# Altium schematic sheet ANALOG-FRONTEND.SchDoc
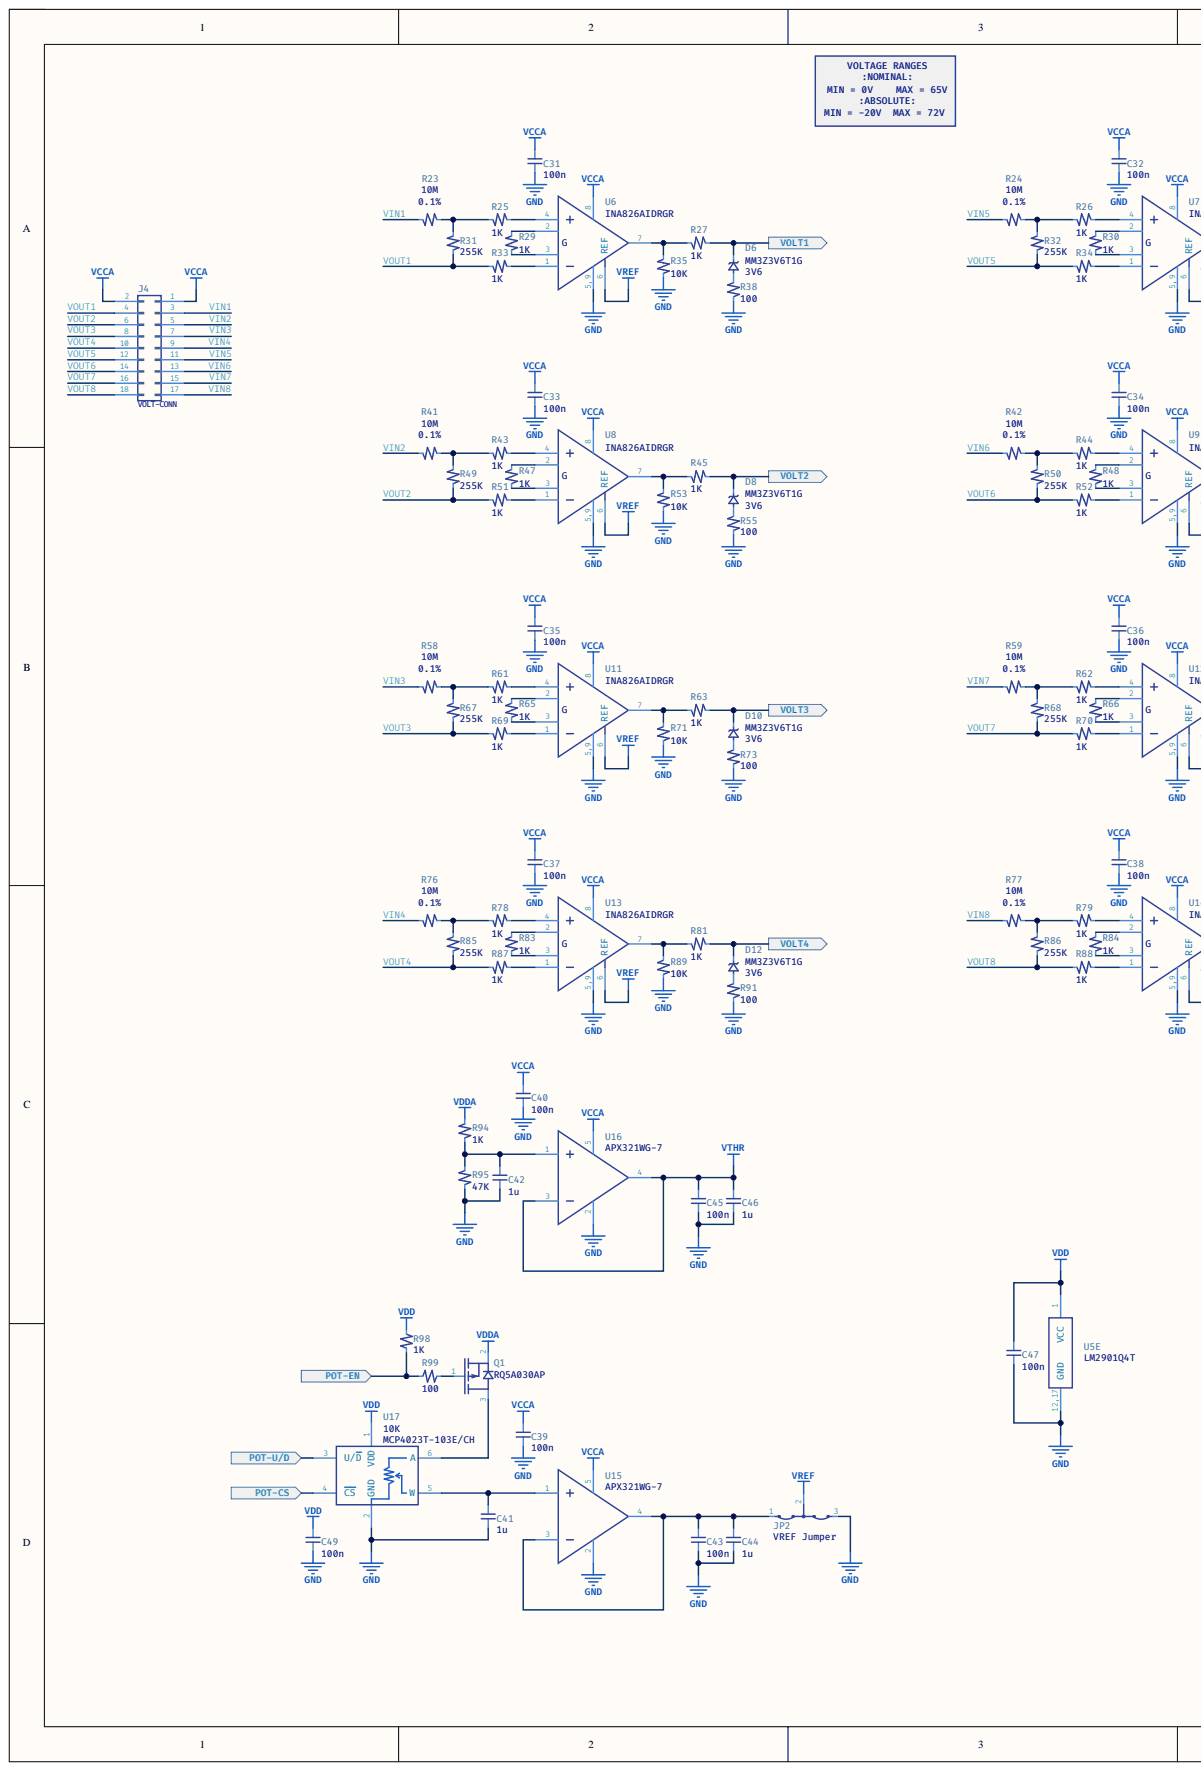
[diagram p0: ANALOG-FRONTEND.SchDoc, left]
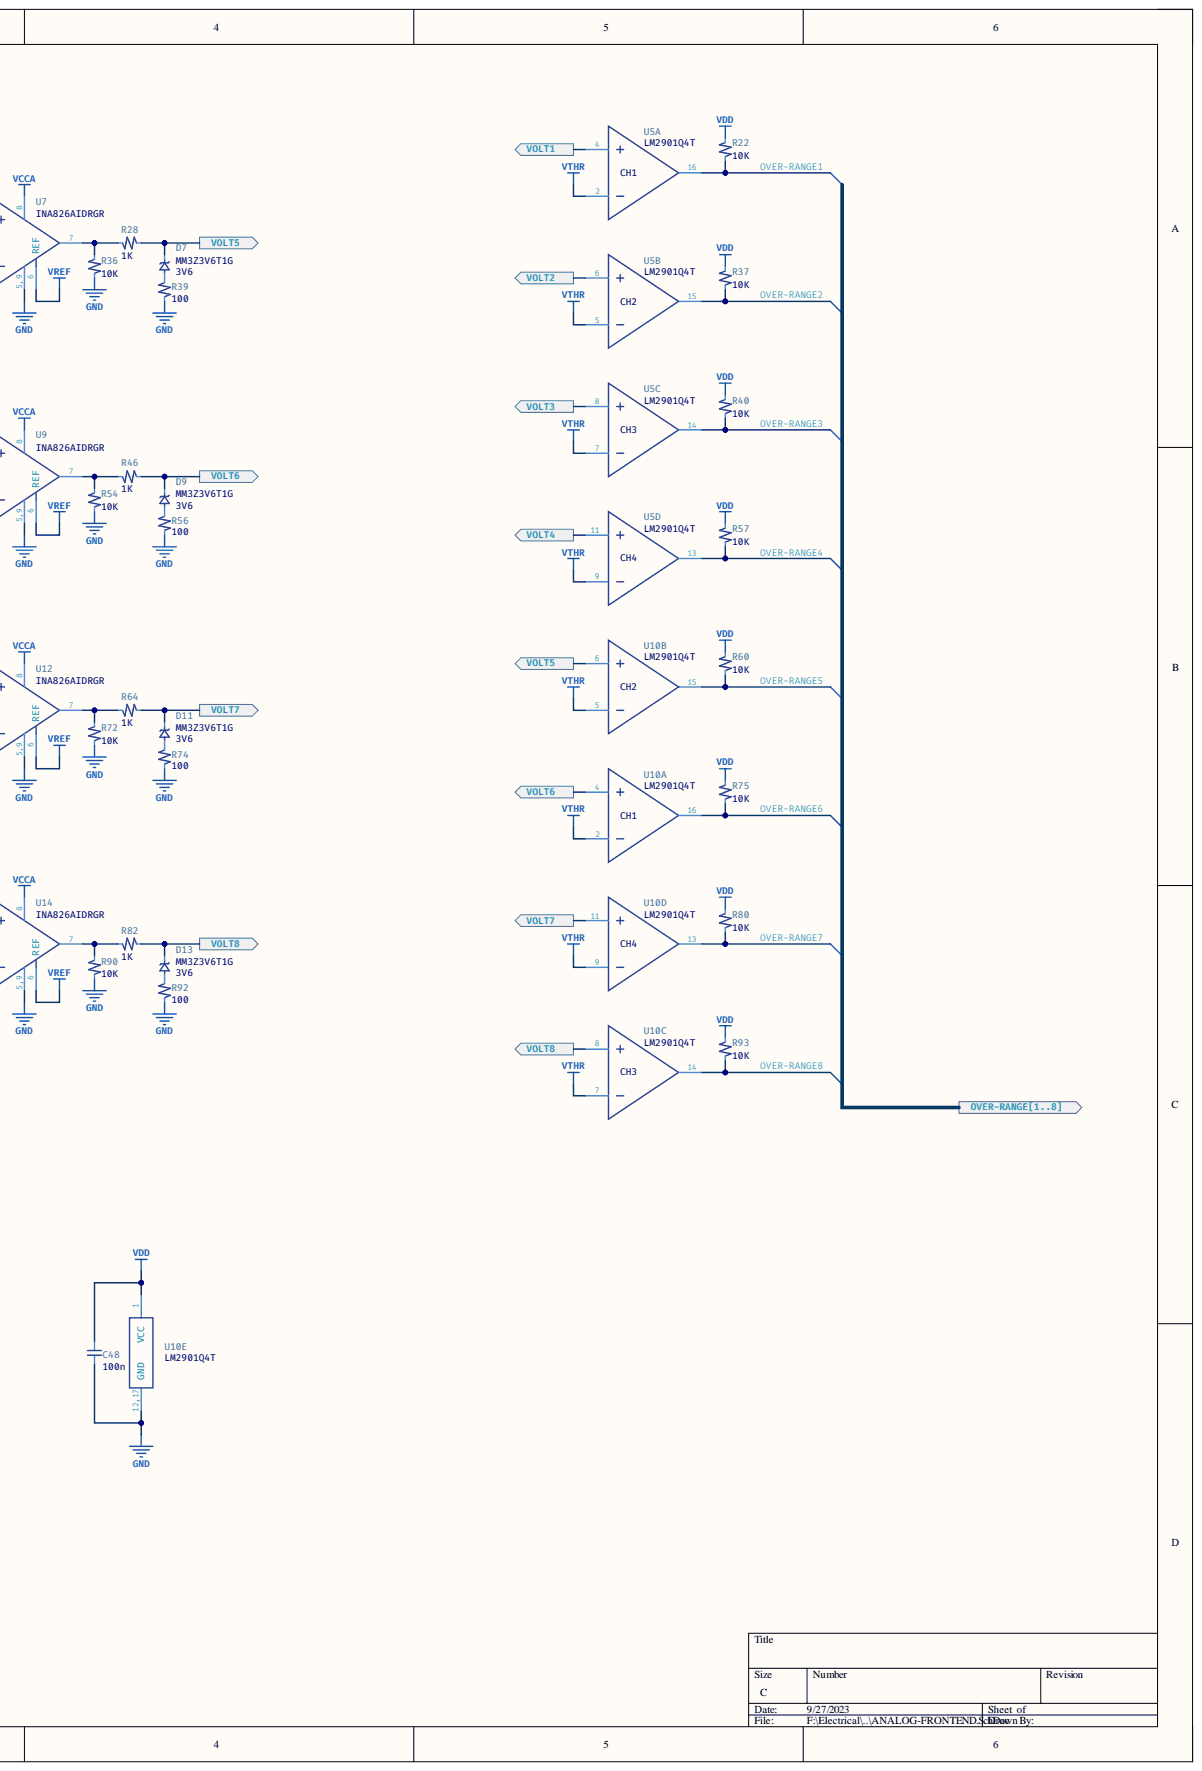
[diagram p1: ANALOG-FRONTEND.SchDoc, right]

## Parts
C31  value 100n  [CAP-0402]  (hidden comment: Generic Capacitor)
C32  value 100n  [CAP-0402]  (hidden comment: Generic Capacitor)
C33  value 100n  [CAP-0402]  (hidden comment: Generic Capacitor)
C34  value 100n  [CAP-0402]  (hidden comment: Generic Capacitor)
C35  value 100n  [CAP-0402]  (hidden comment: Generic Capacitor)
C36  value 100n  [CAP-0402]  (hidden comment: Generic Capacitor)
C37  value 100n  [CAP-0402]  (hidden comment: Generic Capacitor)
C38  value 100n  [CAP-0402]  (hidden comment: Generic Capacitor)
C39  value 100n  [CAP-0402]  (hidden comment: Generic Capacitor)
C40  value 100n  [CAP-0402]  (hidden comment: Generic Capacitor)
C41  value 1u  [CAP-0603]  (hidden comment: Generic Capacitor)
C42  value 1u  [CAP-0603]  (hidden comment: Generic Capacitor)
C43  value 100n  [CAP-0402]  (hidden comment: Generic Capacitor)
C44  value 1u  [CAP-0603]  (hidden comment: Generic Capacitor)
C45  value 100n  [CAP-0402]  (hidden comment: Generic Capacitor)
C46  value 1u  [CAP-0603]  (hidden comment: Generic Capacitor)
C47  value 100n  [CAP-0402]  (hidden comment: Generic Capacitor)
C48  value 100n  [CAP-0402]  (hidden comment: Generic Capacitor)
C49  value 100n  [CAP-0402]  (hidden comment: Generic Capacitor)
D6  value MM3Z3V6T1G  Supplier Part Number 9: MM3Z3V6T1G  VZ: 3V6  [D-SOD-323]  (hidden comment: 3.6V Zener Diode)
D7  value MM3Z3V6T1G  Supplier Part Number 9: MM3Z3V6T1G  VZ: 3V6  [D-SOD-323]  (hidden comment: 3.6V Zener Diode)
D8  value MM3Z3V6T1G  Supplier Part Number 9: MM3Z3V6T1G  VZ: 3V6  [D-SOD-323]  (hidden comment: 3.6V Zener Diode)
D9  value MM3Z3V6T1G  Supplier Part Number 9: MM3Z3V6T1G  VZ: 3V6  [D-SOD-323]  (hidden comment: 3.6V Zener Diode)
D10  value MM3Z3V6T1G  Supplier Part Number 9: MM3Z3V6T1G  VZ: 3V6  [D-SOD-323]  (hidden comment: 3.6V Zener Diode)
D11  value MM3Z3V6T1G  Supplier Part Number 9: MM3Z3V6T1G  VZ: 3V6  [D-SOD-323]  (hidden comment: 3.6V Zener Diode)
D12  value MM3Z3V6T1G  Supplier Part Number 9: MM3Z3V6T1G  VZ: 3V6  [D-SOD-323]  (hidden comment: 3.6V Zener Diode)
D13  value MM3Z3V6T1G  Supplier Part Number 9: MM3Z3V6T1G  VZ: 3V6  [D-SOD-323]  (hidden comment: 3.6V Zener Diode)
J4  value VOLT-CONN  (hidden comment: Conn_02x09_Odd_Even)
JP2  value VREF Jumper  [PinHeader_1x03_P2.54mm_Vertical]  (hidden comment: VREF Selection Jumper)
Q1  value RQ5A030AP  [SOT-23-3]  (hidden comment: P-Ch SIG MOSFET 12V 3A)
R22  value 10K  [RES-0402]  (hidden comment: General Resistor)
R23  value 10M  Tolerance: 0.1%  [RES-1206]  (hidden comment: High Stability Precision Resistor)
R24  value 10M  Tolerance: 0.1%  [RES-1206]  (hidden comment: High Stability Precision Resistor)
R25  value 1K  [RES-0402]  (hidden comment: General Resistor)
R26  value 1K  [RES-0402]  (hidden comment: General Resistor)
R27  value 1K  [RES-0402]  (hidden comment: General Resistor)
R28  value 1K  [RES-0402]  (hidden comment: General Resistor)
R29  value 1K  [RES-0402]  (hidden comment: General Resistor)
R30  value 1K  [RES-0402]  (hidden comment: General Resistor)
R31  value 255K  [RES-1206]  (hidden comment: Precision Thin Film Resistor)
R32  value 255K  [RES-1206]  (hidden comment: Precision Thin Film Resistor)
R33  value 1K  [RES-0402]  (hidden comment: General Resistor)
R34  value 1K  [RES-0402]  (hidden comment: General Resistor)
R35  value 10K  [RES-0402]  (hidden comment: General Resistor)
R36  value 10K  [RES-0402]  (hidden comment: General Resistor)
R37  value 10K  [RES-0402]  (hidden comment: General Resistor)
R38  value 100  [RES-0402]  (hidden comment: General Resistor)
R39  value 100  [RES-0402]  (hidden comment: General Resistor)
R40  value 10K  [RES-0402]  (hidden comment: General Resistor)
R41  value 10M  Tolerance: 0.1%  [RES-1206]  (hidden comment: High Stability Precision Resistor)
R42  value 10M  Tolerance: 0.1%  [RES-1206]  (hidden comment: High Stability Precision Resistor)
R43  value 1K  [RES-0402]  (hidden comment: General Resistor)
R44  value 1K  [RES-0402]  (hidden comment: General Resistor)
R45  value 1K  [RES-0402]  (hidden comment: General Resistor)
R46  value 1K  [RES-0402]  (hidden comment: General Resistor)
R47  value 1K  [RES-0402]  (hidden comment: General Resistor)
R48  value 1K  [RES-0402]  (hidden comment: General Resistor)
R49  value 255K  [RES-1206]  (hidden comment: Precision Thin Film Resistor)
R50  value 255K  [RES-1206]  (hidden comment: Precision Thin Film Resistor)
R51  value 1K  [RES-0402]  (hidden comment: General Resistor)
R52  value 1K  [RES-0402]  (hidden comment: General Resistor)
R53  value 10K  [RES-0402]  (hidden comment: General Resistor)
R54  value 10K  [RES-0402]  (hidden comment: General Resistor)
R55  value 100  [RES-0402]  (hidden comment: General Resistor)
R56  value 100  [RES-0402]  (hidden comment: General Resistor)
R57  value 10K  [RES-0402]  (hidden comment: General Resistor)
R58  value 10M  Tolerance: 0.1%  [RES-1206]  (hidden comment: High Stability Precision Resistor)
R59  value 10M  Tolerance: 0.1%  [RES-1206]  (hidden comment: High Stability Precision Resistor)
R60  value 10K  [RES-0402]  (hidden comment: General Resistor)
R61  value 1K  [RES-0402]  (hidden comment: General Resistor)
R62  value 1K  [RES-0402]  (hidden comment: General Resistor)
R63  value 1K  [RES-0402]  (hidden comment: General Resistor)
R64  value 1K  [RES-0402]  (hidden comment: General Resistor)
R65  value 1K  [RES-0402]  (hidden comment: General Resistor)
R66  value 1K  [RES-0402]  (hidden comment: General Resistor)
R67  value 255K  [RES-1206]  (hidden comment: Precision Thin Film Resistor)
R68  value 255K  [RES-1206]  (hidden comment: Precision Thin Film Resistor)
R69  value 1K  [RES-0402]  (hidden comment: General Resistor)
R70  value 1K  [RES-0402]  (hidden comment: General Resistor)
R71  value 10K  [RES-0402]  (hidden comment: General Resistor)
R72  value 10K  [RES-0402]  (hidden comment: General Resistor)
R73  value 100  [RES-0402]  (hidden comment: General Resistor)
R74  value 100  [RES-0402]  (hidden comment: General Resistor)
R75  value 10K  [RES-0402]  (hidden comment: General Resistor)
R76  value 10M  Tolerance: 0.1%  [RES-1206]  (hidden comment: High Stability Precision Resistor)
R77  value 10M  Tolerance: 0.1%  [RES-1206]  (hidden comment: High Stability Precision Resistor)
R78  value 1K  [RES-0402]  (hidden comment: General Resistor)
R79  value 1K  [RES-0402]  (hidden comment: General Resistor)
R80  value 10K  [RES-0402]  (hidden comment: General Resistor)
R81  value 1K  [RES-0402]  (hidden comment: General Resistor)
R82  value 1K  [RES-0402]  (hidden comment: General Resistor)
R83  value 1K  [RES-0402]  (hidden comment: General Resistor)
R84  value 1K  [RES-0402]  (hidden comment: General Resistor)
R85  value 255K  [RES-1206]  (hidden comment: Precision Thin Film Resistor)
R86  value 255K  [RES-1206]  (hidden comment: Precision Thin Film Resistor)
R87  value 1K  [RES-0402]  (hidden comment: General Resistor)
R88  value 1K  [RES-0402]  (hidden comment: General Resistor)
R89  value 10K  [RES-0402]  (hidden comment: General Resistor)
R90  value 10K  [RES-0402]  (hidden comment: General Resistor)
R91  value 100  [RES-0402]  (hidden comment: General Resistor)
R92  value 100  [RES-0402]  (hidden comment: General Resistor)
R93  value 10K  [RES-0402]  (hidden comment: General Resistor)
R94  value 1K  [RES-0402]  (hidden comment: General Resistor)
R95  value 47K  [RES-0402]  (hidden comment: General Resistor)
R98  value 1K  [RES-0402]  (hidden comment: General Resistor)
R99  value 100  [RES-0402]  (hidden comment: General Resistor)
U5  value LM2901Q4T  [QFN-16-1EP_3x3mm_P0.5mm_EP1.7x1.7mm]  (hidden comment: IC COMPARATOR 4 GP)  (parts A,B,C,D,E)
U6  value INA826AIDRGR  [DFN-8-1EP_3x3mm_P0.5mm_EP1.2x2mm]  (hidden comment: IC INST AMP 1 CIRCUIT)
U7  value INA826AIDRGR  [DFN-8-1EP_3x3mm_P0.5mm_EP1.2x2mm]  (hidden comment: IC INST AMP 1 CIRCUIT)
U8  value INA826AIDRGR  [DFN-8-1EP_3x3mm_P0.5mm_EP1.2x2mm]  (hidden comment: IC INST AMP 1 CIRCUIT)
U9  value INA826AIDRGR  [DFN-8-1EP_3x3mm_P0.5mm_EP1.2x2mm]  (hidden comment: IC INST AMP 1 CIRCUIT)
U10  value LM2901Q4T  [QFN-16-1EP_3x3mm_P0.5mm_EP1.7x1.7mm]  (hidden comment: IC COMPARATOR 4 GP)  (parts A,B,C,D,E)
U11  value INA826AIDRGR  [DFN-8-1EP_3x3mm_P0.5mm_EP1.2x2mm]  (hidden comment: IC INST AMP 1 CIRCUIT)
U12  value INA826AIDRGR  [DFN-8-1EP_3x3mm_P0.5mm_EP1.2x2mm]  (hidden comment: IC INST AMP 1 CIRCUIT)
U13  value INA826AIDRGR  [DFN-8-1EP_3x3mm_P0.5mm_EP1.2x2mm]  (hidden comment: IC INST AMP 1 CIRCUIT)
U14  value INA826AIDRGR  [DFN-8-1EP_3x3mm_P0.5mm_EP1.2x2mm]  (hidden comment: IC INST AMP 1 CIRCUIT)
U15  value APX321WG-7  [SOT-23-5]  (hidden comment: IC OPAMP GP 1 CIRCUIT)
U16  value APX321WG-7  [SOT-23-5]  (hidden comment: IC OPAMP GP 1 CIRCUIT)
U17  value MCP4023T-103E/CH  Resistance: 10K  [SOT-23-6]  (hidden comment: IC DGTL POT 10KOHM 64TAP)

## Ports
OVER-RANGE1, OVER-RANGE2, OVER-RANGE3, OVER-RANGE4, OVER-RANGE5, OVER-RANGE6, OVER-RANGE7, OVER-RANGE8, OVER-RANGE[1..8], POT-CS, POT-EN, POT-U/D, VOLT1, VOLT2, VOLT3, VOLT4, VOLT5, VOLT6, VOLT7, VOLT8

## Nets
GND: C31.2 C32.2 C33.2 C34.2 C35.2 C36.2 C37.2 C38.2 C39.2 C40.2 C41.2 C42.2 C43.2 C44.2 C45.2 C46.2 C47.2 C48.2 C49.2 JP2.3 R35.1 R36.1 R38.2 R39.2 R53.1 R54.1 R55.2 R56.2 R71.1 R72.1 R73.2 R74.2 R89.1 R90.1 R91.2 R92.2 R95.2 U5.12,17(GND) U6.5,9 U7.5,9 U8.5,9 U9.5,9 U10.12,17(GND) U11.5,9 U12.5,9 U13.5,9 U14.5,9 U15.2 U16.2 U17.2(GND)
VCCA: C31.1 C32.1 C33.1 C34.1 C35.1 C36.1 C37.1 C38.1 C39.1 C40.1 J4.1 J4.2 U6.8 U7.8 U8.8 U9.8 U11.8 U12.8 U13.8 U14.8 U15.5 U16.5
VDD: C47.1 C48.1 C49.1 R22.2 R37.2 R40.2 R57.2 R60.2 R75.2 R80.2 R93.2 R98.1 U5.1(VCC) U10.1(VCC) U17.1(VDD)
VDDA: Q1.2 R94.1
VREF: JP2.2 U6.6(REF) U7.6(REF) U8.6(REF) U9.6(REF) U11.6(REF) U12.6(REF) U13.6(REF) U14.6(REF)
VTHR: C45.1 C46.1 U5.2 U5.5 U5.7 U5.9 U10.2 U10.5 U10.7 U10.9 U16.3 U16.4
OVER-RANGE1: R22.1 U5.16 ; port OVER-RANGE[1..8]  [also labelled ]
OVER-RANGE2: R37.1 U5.15 ; port OVER-RANGE[1..8]  [also labelled ]
OVER-RANGE3: R40.1 U5.14 ; port OVER-RANGE[1..8]  [also labelled ]
OVER-RANGE4: R57.1 U5.13 ; port OVER-RANGE[1..8]  [also labelled ]
OVER-RANGE5: R60.1 U10.15 ; port OVER-RANGE[1..8]  [also labelled ]
OVER-RANGE6: R75.1 U10.16 ; port OVER-RANGE[1..8]  [also labelled ]
OVER-RANGE7: R80.1 U10.13 ; port OVER-RANGE[1..8]  [also labelled ]
OVER-RANGE8: R93.1 U10.14 ; port OVER-RANGE[1..8]  [also labelled ]
POT-CS: U17.4(CS) ; port POT-CS
POT-EN: R98.2 R99.2 ; port POT-EN
POT-U/D: U17.3(U/D) ; port POT-U/D
VIN1: J4.3 R23.2
VIN2: J4.5 R41.2
VIN3: J4.7 R58.2
VIN4: J4.9 R76.2
VIN5: J4.11 R24.2
VIN6: J4.13 R42.2
VIN7: J4.15 R59.2
VIN8: J4.17 R77.2
VOLT1: D6.1 R27.1 U5.4 ; port VOLT1
VOLT2: D8.1 R45.1 U5.6 ; port VOLT2
VOLT3: D10.1 R63.1 U5.8 ; port VOLT3
VOLT4: D12.1 R81.1 U5.11 ; port VOLT4
VOLT5: D7.1 R28.1 U10.6 ; port VOLT5
VOLT6: D9.1 R46.1 U10.4 ; port VOLT6
VOLT7: D11.1 R64.1 U10.11 ; port VOLT7
VOLT8: D13.1 R82.1 U10.8 ; port VOLT8
VOUT1: J4.4 R31.2 R33.2
VOUT2: J4.6 R49.2 R51.2
VOUT3: J4.8 R67.2 R69.2
VOUT4: J4.10 R85.2 R87.2
VOUT5: J4.12 R32.2 R34.2
VOUT6: J4.14 R50.2 R52.2
VOUT7: J4.16 R68.2 R70.2
VOUT8: J4.18 R86.2 R88.2
NetC41_1: C41.1 U15.1 U17.5(W)
NetC42_1: C42.1 R94.2 R95.1 U16.1
NetC43_1: C43.1 C44.1 JP2.1 U15.3 U15.4
NetD6_2: D6.2 R38.1
NetD7_2: D7.2 R39.1
NetD8_2: D8.2 R55.1
NetD9_2: D9.2 R56.1
NetD10_2: D10.2 R73.1
NetD11_2: D11.2 R74.1
NetD12_2: D12.2 R91.1
NetD13_2: D13.2 R92.1
NetQ1_1: Q1.1 R99.1
NetQ1_3: Q1.3 U17.6(A)
NetR23_1: R23.1 R25.2 R31.1
NetR24_1: R24.1 R26.2 R32.1
NetR25_1: R25.1 U6.4
NetR26_1: R26.1 U7.4
NetR27_2: R27.2 R35.2 U6.7
NetR28_2: R28.2 R36.2 U7.7
NetR29_1: R29.1 U6.3
NetR29_2: R29.2 U6.2
NetR30_1: R30.1 U7.3
NetR30_2: R30.2 U7.2
NetR33_1: R33.1 U6.1
NetR34_1: R34.1 U7.1
NetR41_1: R41.1 R43.2 R49.1
NetR42_1: R42.1 R44.2 R50.1
NetR43_1: R43.1 U8.4
NetR44_1: R44.1 U9.4
NetR45_2: R45.2 R53.2 U8.7
NetR46_2: R46.2 R54.2 U9.7
NetR47_1: R47.1 U8.3
NetR47_2: R47.2 U8.2
NetR48_1: R48.1 U9.3
NetR48_2: R48.2 U9.2
NetR51_1: R51.1 U8.1
NetR52_1: R52.1 U9.1
NetR58_1: R58.1 R61.2 R67.1
NetR59_1: R59.1 R62.2 R68.1
NetR61_1: R61.1 U11.4
NetR62_1: R62.1 U12.4
NetR63_2: R63.2 R71.2 U11.7
NetR64_2: R64.2 R72.2 U12.7
NetR65_1: R65.1 U11.3
NetR65_2: R65.2 U11.2
NetR66_1: R66.1 U12.3
NetR66_2: R66.2 U12.2
NetR69_1: R69.1 U11.1
NetR70_1: R70.1 U12.1
NetR76_1: R76.1 R78.2 R85.1
NetR77_1: R77.1 R79.2 R86.1
NetR78_1: R78.1 U13.4
NetR79_1: R79.1 U14.4
NetR81_2: R81.2 R89.2 U13.7
NetR82_2: R82.2 R90.2 U14.7
NetR83_1: R83.1 U13.3
NetR83_2: R83.2 U13.2
NetR84_1: R84.1 U14.3
NetR84_2: R84.2 U14.2
NetR87_1: R87.1 U13.1
NetR88_1: R88.1 U14.1

## Library data of the parts
C31-C49 = C: General un-polarized capacitor; Tolerance: 5%; Component Kind: Standard; Library Name: Daxxn_Device.SchLib
D6-D13 = D-ZE: Zener Diode 3.6 V 300 mW ±6% Surface Mount SOD-323; Component Kind: Standard; ComponentLink1Description: Datasheet; ComponentLink1URL: https://media.digikey.com/pdf/Data%20Sheets/ON%20Semiconductor%20PDFs/MM3ZyyyT1G_SZMM3ZyyyT1G_Series_rev.11_Sep2014.pdf; ComponentLink2Description: LocalDatasheet; Supplier 1: Digi-Key; Supplier 2: Digi-Key; Supplier 3: Digi-Key; Supplier 4: Mouser; Supplier 5: Newark; Supplier 6: Newark; Supplier 7: Newark; Supplier 8: Newark; Supplier 9: Arrow Electronics; Supplier Part Number 1: MM3Z3V6T1GOSCT-ND; Supplier Part Number 2: MM3Z3V6T1GOSDKR-ND; Supplier Part Number 3: MM3Z3V6T1GOSTR-ND; Supplier Part Number 4: 863-MM3Z3V6T1G; Supplier Part Number 5: 01P0395; Supplier Part Number 6: 31Y2922; Supplier Part Number 7: 27AC9170; Supplier Part Number 8: 80Y9472; ComponentLink2URL: F:\Electrical\Datasheets\MM3Z.pdf; Library Name: Daxxn_Device.SchLib
JP2 = Jumper-3Pin: 3-Pin Jumper; Component Kind: Standard; ComponentLink1Description: Datasheet; ComponentLink2Description: LocalDatasheet; Library Name: Daxxn_Device.SchLib
Q1 = RQ5A030AP: P-Channel 12 V 3A (Ta) 1W (Ta) Surface Mount SOT-346T; Component Kind: Standard; ComponentLink1Description: Datasheet; ComponentLink1URL: https://fscdn.rohm.com/en/products/databook/datasheet/discrete/transistor/mosfet/rq5a030aptl-e.pdf; ComponentLink2Description: LocalDatasheet; Supplier 1: Digi-Key; Supplier 2: Digi-Key; Supplier 3: Digi-Key; Supplier 4: Newark; Supplier 5: Mouser; Supplier Part Number 1: RQ5A030APTLCT-ND; Supplier Part Number 2: RQ5A030APTLDKR-ND; Supplier Part Number 3: RQ5A030APTLTR-ND; Supplier Part Number 4: 82AC3047; Supplier Part Number 5: 755-RQ5A030APTL; ComponentLink2URL: F:\Electrical\Datasheets\RQ5A030AP.pdf; Library Name: Daxxn_Transistors.SchLib
R22 R25-R30 R33-R40 R43-R48 R51-R57 R60-R66 R69-R75 R78-R84 R87-R95 R98 R99 = R: General purpose resistor; Tolerance: 1%; Component Kind: Standard; Library Name: Daxxn_Device.SchLib
R23 R24 R41 R42 R58 R59 R76 R77 = R: 10 MOhms ±0.1% 0.25W, 1/4W Chip Resistor 1206 (3216 Metric) Anti-Sulfur, Automotive AEC-Q200, Moisture Resistant Thin Film; PartNumber: MCA1206MD1005BP100; Component Kind: Standard; ComponentLink1Description: Datasheet; ComponentLink1URL: https://www.vishay.com/docs/28952/mcs0402at-mct0603at-mcu0805at-mca1206at.pdf; ComponentLink2Description: LocalDatasheet; Supplier 1: Digi-Key; Supplier 2: Digi-Key; Supplier 3: Digi-Key; Supplier 4: Mouser; Supplier 5: Newark; Supplier Part Number 1: 749-MCA1206MD1005BP100CT-ND; Supplier Part Number 2: 749-MCA1206MD1005BP100DKR-ND; Supplier Part Number 3: 749-MCA1206MD1005BP100TR-ND; Supplier Part Number 4: 594-MCA1206MD1005BP1; Supplier Part Number 5: 06AJ9218; ComponentLink2URL: F:\Electrical\Datasheets\MCA1206.pdf; Library Name: Daxxn_Device.SchLib
R31 R32 R49 R50 R67 R68 R85 R86 = R: 255 KOhms ±0.1% 0.4W, 2/5W Chip Resistor 1206 (3216 Metric) Anti-Sulfur, Automotive AEC-Q200 Thin Film; PartNumber: RQ73C2B255KBTD; Component Kind: Standard; ComponentLink1Description: Datasheet; ComponentLink1URL: https://www.te.com/commerce/DocumentDelivery/DDEController?Action=showdoc&DocId=Data+Sheet%7F1773270-2%7FB%7Fpdf%7FEnglish%7FENG_DS_1773270-2_B.pdf%7F2176392-6; ComponentLink2Description: LocalDatasheet; Supplier 1: Digi-Key; Supplier 2: Digi-Key; Supplier 3: Digi-Key; Supplier 4: Newark; Supplier 5: Newark; Supplier Part Number 1: A139770CT-ND; Supplier Part Number 2: A139770DKR-ND; Supplier Part Number 3: A139770TR-ND; Supplier Part Number 4: 96AC7963; Supplier Part Number 5: 97AC2734; Tolerance: 0.1%; ComponentLink2URL: F:\Electrical\Datasheets\RQ73-Series.pdf; Library Name: Daxxn_Device.SchLib
U5 U10 = LM2901Q4T: Comparator General Purpose CMOS, DTL, MOS, Open-Collector, TTL QFN-16; Component Kind: Standard; ComponentLink1Description: Datasheet; ComponentLink1URL: https://www.st.com/content/ccc/resource/technical/document/datasheet/ac/48/4f/c5/c8/5f/4e/66/CD00000532.pdf/files/CD00000532.pdf/jcr:content/translations/en.CD00000532.pdf; ComponentLink2Description: LocalDatasheet; Supplier 1: Digi-Key; Supplier 2: Digi-Key; Supplier 3: Digi-Key; Supplier 4: Mouser; Supplier 5: Newark; Supplier 6: Newark; Supplier 7: Avnet; Supplier 8: Arrow Electronics; Supplier Part Number 1: 497-12401-1-ND; Supplier Part Number 2: 497-12401-6-ND; Supplier Part Number 3: 497-12401-2-ND; Supplier Part Number 4: 511-LM2901Q4T; Supplier Part Number 5: 25AH9758; Supplier Part Number 6: 23AC6376; Supplier Part Number 7: LM2901Q4T; Supplier Part Number 8: LM2901Q4T; ComponentLink2URL: F:\Electrical\Datasheets\LM2901Q4T.pdf; Library Name: Daxxn_OPAMP.SchLib
U6-U9 U11-U14 = INA826AIDRGR: Instrumentation Amplifier 1 Circuit Rail-to-Rail SON-8; Component Kind: Standard; ComponentLink1Description: Datasheet; ComponentLink1URL: https://www.ti.com/lit/ds/symlink/ina826.pdf?HQS=dis-dk-null-digikeymode-dsf-pf-null-wwe&ts=1691009480661&ref_url=https%253A%252F%252Fwww.ti.com%252Fgeneral%252Fdocs%252Fsuppproductinfo.tsp%253FdistId%253D10%2526gotoUrl%253Dhttps%253A%252F%252Fwww.ti.com%252Flit%252Fgpn%252Fina826; ComponentLink2Description: LocalDatasheet; Supplier 1: Digi-Key; Supplier 2: Digi-Key; Supplier 3: Digi-Key; Supplier 4: Mouser; Supplier Part Number 1: 296-36596-1-ND; Supplier Part Number 2: 296-36596-6-ND; Supplier Part Number 3: 296-36596-2-ND; Supplier Part Number 4: 595-INA826AIDRGR; ComponentLink2URL: F:\Electrical\Datasheets\INA826.pdf; Library Name: Daxxn_OPAMP.SchLib
U15 U16 = APX321: General Purpose Amplifier 1 Circuit Rail-to-Rail SOT-25; Component Kind: Standard; ComponentLink1Description: Datasheet; ComponentLink1URL: https://www.diodes.com/assets/Datasheets/APX321_358.pdf; ComponentLink2Description: LocalDatasheet; Supplier 1: Digi-Key; Supplier 2: Digi-Key; Supplier 3: Digi-Key; Supplier 4: Mouser; Supplier 5: Newark; Supplier Part Number 1: APX321WGDICT-ND; Supplier Part Number 2: APX321WGDIDKR-ND; Supplier Part Number 3: APX321WGDITR-ND; Supplier Part Number 4: 621-APX321WG-7; Supplier Part Number 5: 79R5808; ComponentLink2URL: F:\Electrical\Datasheets\APX321.pdf; Library Name: Daxxn_OPAMP.SchLib
U17 = MCP4023T: Digital Potentiometer 10k Ohm 1 Circuit 64 Taps Up/Down (U/D, CS) Interface SOT-23-6; Component Kind: Standard; ComponentLink1Description: Datasheet; ComponentLink1URL: https://ww1.microchip.com/downloads/en/DeviceDoc/20001945F.pdf; ComponentLink2Description: LocalDatasheet; ComponentLink2URL: F:\Electrical\Datasheets\MCP4021.pdf; Library Name: Daxxn_IC.SchLib
J4 = Conn_02x09_Odd_Even: Component Kind: Standard; Library Name: Connector_Generic.SchLib
